# Altium schematic sheet overview.SchDoc
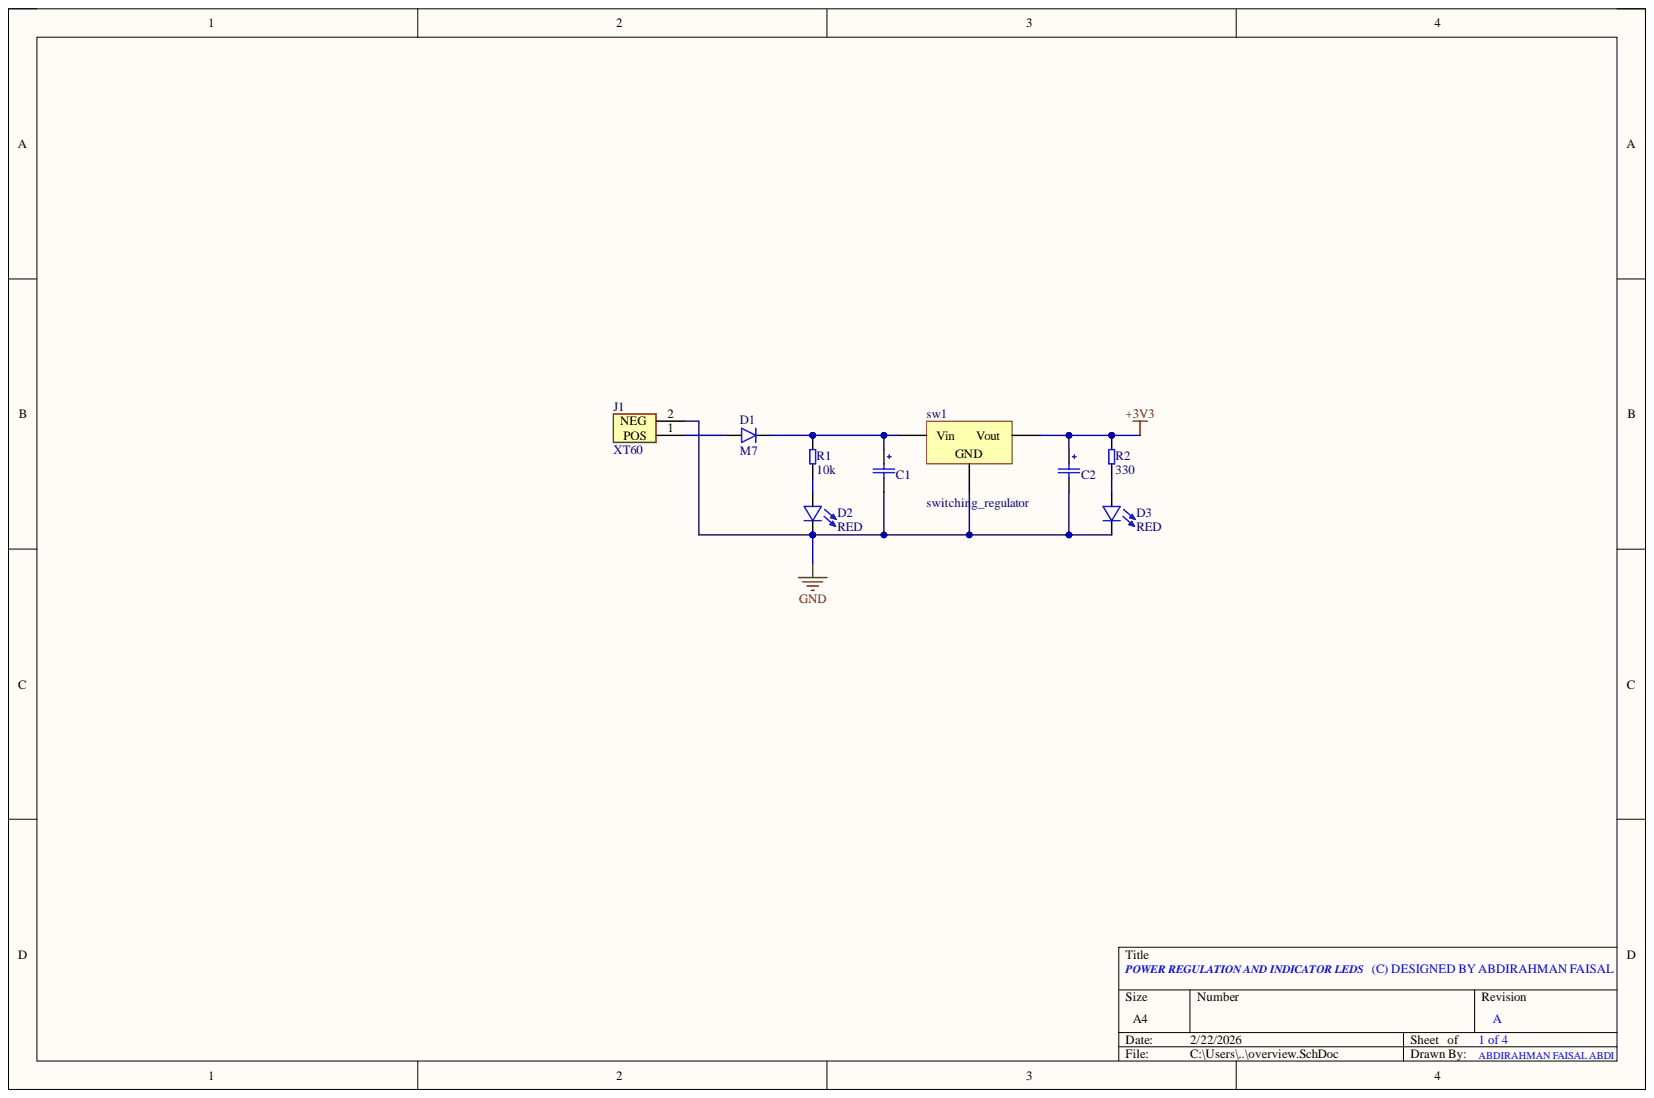
[diagram p0: overview.SchDoc]

## Parts
C1  UCM1H331MNL1GS  [CAP_UCD_10X10_NCH]  (value not drawn)
C2  UCM1H331MNL1GS  [CAP_UCD_10X10_NCH]  (value not drawn)
D1  M7  [DIOM505270X240]
D2  RED  [LED_VLMS1300-GS08]
D3  RED  [LED_VLMS1300-GS08]
J1  XT60  [AMASS_XT60PW-M]
R1  10k  [R0603]
R2  330  [R0603]
sw1  switching_regulator  [SWITCHING REGULATOR]

## Nets
+3V3: C2.1 R2.1 sw1.3
GND: C1.2 C2.2 D2.C D3.C J1.2 sw1.2
NetC1_1: C1.1 D1.C R1.2 sw1.1
NetD1_A: D1.A J1.1
NetD2_A: D2.A R1.1
NetD3_A: D3.A R2.2

## Library data of the parts
C1 C2 = UCM1H331MNL1GS: CAP ALUM 330UF 20% 50V SMD; Manufacturer: Nichicon; Category: Aluminum Electrolytic Capacitors; Component Kind: Standard; ComponentLink1Description: http://uk.rs-online.com/webdocs/13bc/0900766b813bca52.pdf?src-supplier=Rs; ComponentLink1URL: http://uk.rs-online.com/webdocs/13bc/0900766b813bca52.pdf?src-supplier=Rs; ComponentLink2Description: http://www.mouser.com/ds/2/293/e-ucm-883824.pdf?src-supplier=Mouser; ComponentLink2URL: http://www.mouser.com/ds/2/293/e-ucm-883824.pdf?src-supplier=Mouser; ComponentLink3Description: http://nichicon-us.com/english/products/pdfs/e-ucm.pdf?src-supplier=Digikey; ComponentLink3URL: http://nichicon-us.com/english/products/pdfs/e-ucm.pdf?src-supplier=Digikey; ComponentLink4Description: https://datasheet.octopart.com/UCM1H331MNL1GS-Nichicon-datasheet-151191225.pdf?src-supplier=Future+Electronics; ComponentLink4URL: https://datasheet.octopart.com/UCM1H331MNL1GS-Nichicon-datasheet-151191225.pdf?src-supplier=Future+Electronics; ComponentLink5Description: https://datasheet.octopart.com/UCM1H331MNL1GS-Nichicon-datasheet-66487647.pdf?src-supplier=TME; ComponentLink5URL: https://datasheet.octopart.com/UCM1H331MNL1GS-Nichicon-datasheet-66487647.pdf?src-supplier=TME; LCSC part number: C729686; Manufacturer Part Number: UCM1H331MNL1GS; Pricing 1: 1=1.18, 10=0.757, 50=0.5786, 100=0.5221 (USD); RoHS: Yes; Stock 1: 13467; Supplier 1: Digikey; Library Name: arfaisal.IntLib
D1 = M7: DIODE STANDARD 1000V 1A DO214AC; Manufacturer: Diotec; Category: Rectifier Diodes; Component Kind: Standard; ComponentLink1Description: https://datasheet.octopart.com/M7-Diotec-datasheet-113243034.pdf?src-supplier=TME; ComponentLink1URL: https://datasheet.octopart.com/M7-Diotec-datasheet-113243034.pdf?src-supplier=TME; LCSC part number: C85148; Manufacturer Part Number: M7; Pricing 1: 1=0.1, 10=0.055, 100=0.0402, 500=0.03234, 1000=0.02906, 2000=0.0257 (USD); RoHS: Yes; Stock 1: 88613; Supplier 1: Digikey; Library Name: arfaisal.IntLib
D2 D3 = VLMS1300-GS08: LED RED 0603 SMD; Manufacturer: Vishay Semiconductors; Dominant Wavelength: 631nm; Forward Current: 20mA; Forward Voltage: 2V; Lens Style: Rectangular,Clear; Luminous Intensity: 54mcd; Number of LEDs: 1; Power Rating: 75mW; Viewing Angle: 130°; Case Code (Imperial): 0603; Case Code (Metric): 1608; Case/Package: 0603; Category: LEDs; Color: Red; Component Kind: Standard; ComponentLink1Description: https://datasheet.ciiva.com/26322/vlmo1300-26322153.pdf?src-supplier=Element14; ComponentLink1URL: https://datasheet.ciiva.com/26322/vlmo1300-26322153.pdf?src-supplier=Element14; ComponentLink2Description: https://datasheet.ciiva.com/8028/vlmo1300-8028047.pdf?src-supplier=Element14; ComponentLink2URL: https://datasheet.ciiva.com/8028/vlmo1300-8028047.pdf?src-supplier=Element14; ComponentLink3Description: https://datasheet.ciiva.com/9333/vlmo1300-9333743.pdf?src-supplier=Avnet; ComponentLink3URL: https://datasheet.ciiva.com/9333/vlmo1300-9333743.pdf?src-supplier=Avnet; ComponentLink4Description: https://datasheet.ciiva.com/16510/vlmo1300-16510985.pdf?src-supplier=Future+Electronics; ComponentLink4URL: https://datasheet.ciiva.com/16510/vlmo1300-16510985.pdf?src-supplier=Future+Electronics; Height: 0.8mm; Illumination Color: Red; LCSC part number: C83242; Lead Free: Lead Free; Length: 1.6mm; Manufacturer Part Number: VLMS1300-GS08; Max Operating Temperature: 85°C; Min Operating Temperature: -35°C; Mount: Surface Mount; Package Quantity: 1; Packaging: Tape and Reel; Pricing 1: 1=0.21, 10=0.143, 100=0.0998, 500=0.07982, 1000=0.07322 (USD); Radiation Hardening: No; Reach SVHC Compliant: Unknown; RoHS: Yes; RoHS Compliant: Yes; Series: CHipLED; Stock 1: 42495; Supplier 1: Digikey; Test Current: 20mA; Wavelength: 631nm; Width: 0.8mm; Library Name: arfaisal.IntLib
J1 = XT60PW-M: XT60PW Male, yellow; Manufacturer: Unclassified; Category: LDO; Component Kind: Standard; ComponentLink1Description: New link; LCSC part number: C98732; Manufacturer Part Number: XT60PW-M; RoHS: No; Supplier 1: Rs; Library Name: arfaisal.IntLib
R1 = RT0603FRE0710KL: Fixed Resistor, Thin Film, 0.1W, 10000ohm, 75V, 1% +/-Tol, 50ppm/Cel, Surface Mount, 0603; Manufacturer: Yageo; Max Power Dissipation: 100mW; Resistance: 10kR; Temperature Coefficient: 50ppm/°C; Case Code (Imperial): 0603; Case Code (Metric): 1608; Case/Package: 0603; Category: Chip SMD Resistors; Component Kind: Standard; ComponentLink1Description: https://datasheet.ciiva.com/12694/pyu-rt-1-to-0-05-rohs-l-4-12694910.pdf?src-supplier=Mouser; ComponentLink1URL: https://datasheet.ciiva.com/12694/pyu-rt-1-to-0-05-rohs-l-4-12694910.pdf?src-supplier=Mouser; ComponentLink2Description: https://datasheet.ciiva.com/16745/pyu-rt-1-to-0-05-rohs-l-4-16745739.pdf?src-supplier=Future+Electronics; ComponentLink2URL: https://datasheet.ciiva.com/16745/pyu-rt-1-to-0-05-rohs-l-4-16745739.pdf?src-supplier=Future+Electronics; ComponentLink3Description: https://datasheet.ciiva.com/2559/pyu-rt-1-to-0-05-rohs-l-5-2559482.pdf?src-supplier=Digikey; ComponentLink3URL: https://datasheet.ciiva.com/2559/pyu-rt-1-to-0-05-rohs-l-5-2559482.pdf?src-supplier=Digikey; Contact Plating: Tin,Lead; Features: Moisture Resistant; Height: 0.022inch; LCSC part number: C469659; Lead Free: 5697LeadFree; Length: 0.063inch; Manufacturer Part Number: RT0603FRE0710KL; Max Operating Temperature: 125°C; Min Operating Temperature: -55°C; Number of Pins: 2; Number of Terminations: 2; Package Quantity: 5000; Packaging: Tape and Reel; Power Rating: 100mW; Pricing 1: 1=0.1, 10=0.012, 25=0.0116, 50=0.0112, 100=0.0107, 250=0.0102 (USD); Reach SVHC Compliant: Unknown; Resistance Tolerance: 1%; Resistor Type: Thin Film; RoHS: Yes; RoHS Compliant: Yes; Series: RT; Size Code: 0603; Stock 1: 237229; Supplier 1: Digikey; Termination: SMD/SMT; Tolerance: 1%; Voltage Rating: 75V; Voltage Rating (DC): 75V; Width: 0.031inch; Library Name: arfaisal.IntLib
R2 = RC0603FR-07330RL: RES 330 OHM 1% 1/10W 0603; Manufacturer: Yageo; Capacitance: 47uF; ESR (Equivalent Series Resistance): 800mR; Max Power Dissipation: 100mW; Resistance: 330R; Temperature Coefficient: 100ppm/°C; Voltage Rating: 50V; Case Code (Imperial): 0603; Case Code (Metric): 1608; Case/Package: 0603; Category: Chip SMD Resistors; Component Kind: Standard; Depth: 0.8mm; Features: Moisture Resistant; Height: 0.45mm; LCSC part number: C105881; Lead Free: 5697LeadFree; Length: 1.6mm; Manufacturer Part Number: RC0603FR-07330RL; Max Operating Temperature: 155°C; Min Operating Temperature: -55°C; Number of Pins: 2; Number of Terminations: 2; Package Quantity: 1; Packaging: Tape and Reel; Power Rating: 100mW; Pricing 1: 1=0.1, 10=0.009, 100=0.0082, 1000=0.00612, 2500=0.00461 (USD); Reach SVHC Compliant: No; Resistance Tolerance: 1%; Resistor Type: Thick Film; RoHS: Yes; RoHS Compliant: Yes; Series: RC; Size Code: 0603; Stock 1: 425796; Supplier 1: Digikey; Technology: THICK FILM; Termination: SMD/SMT; Tolerance: 1%; Voltage Rating (AC): 50V; Voltage Rating (DC): 50V; Width: 0.8mm; Library Name: arfaisal.IntLib
sw1 = switching_regulator: Component Kind: Standard; Library Name: arfaisal.IntLib
